# Altium schematic sheet LED_Monitor_2.SchDoc
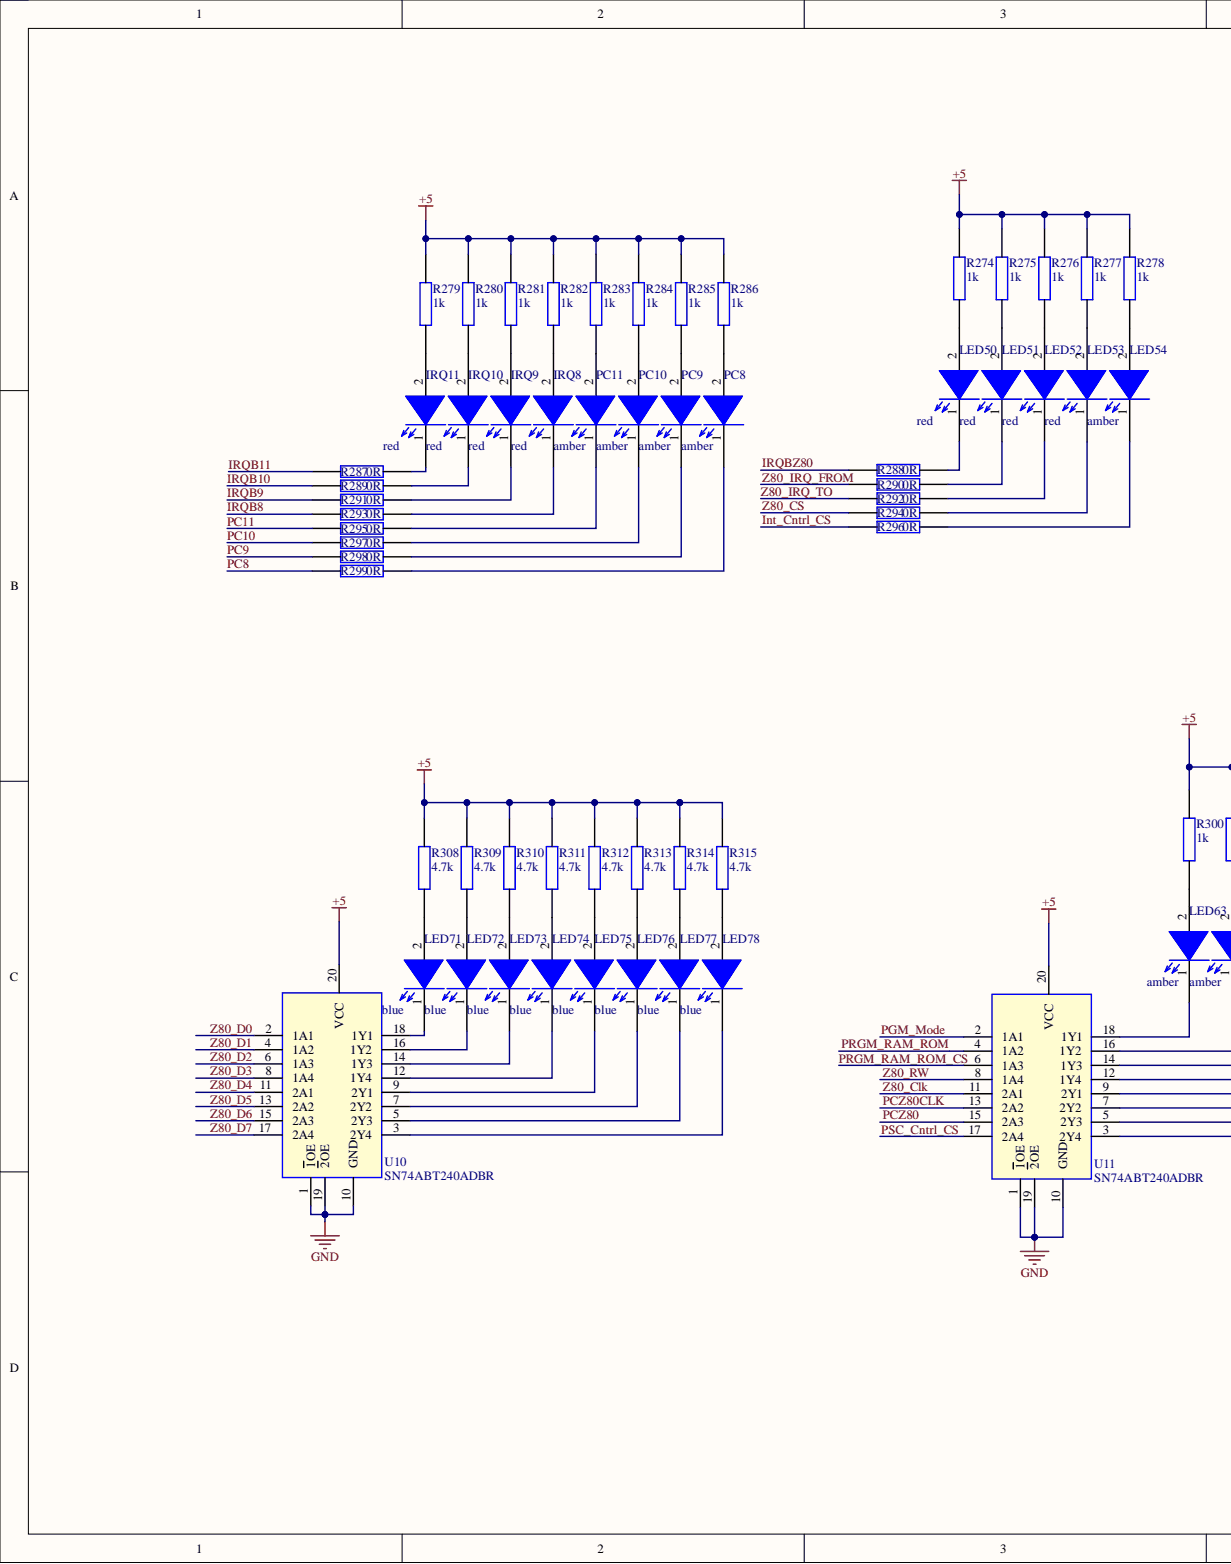
[diagram p0: LED_Monitor_2.SchDoc, left]
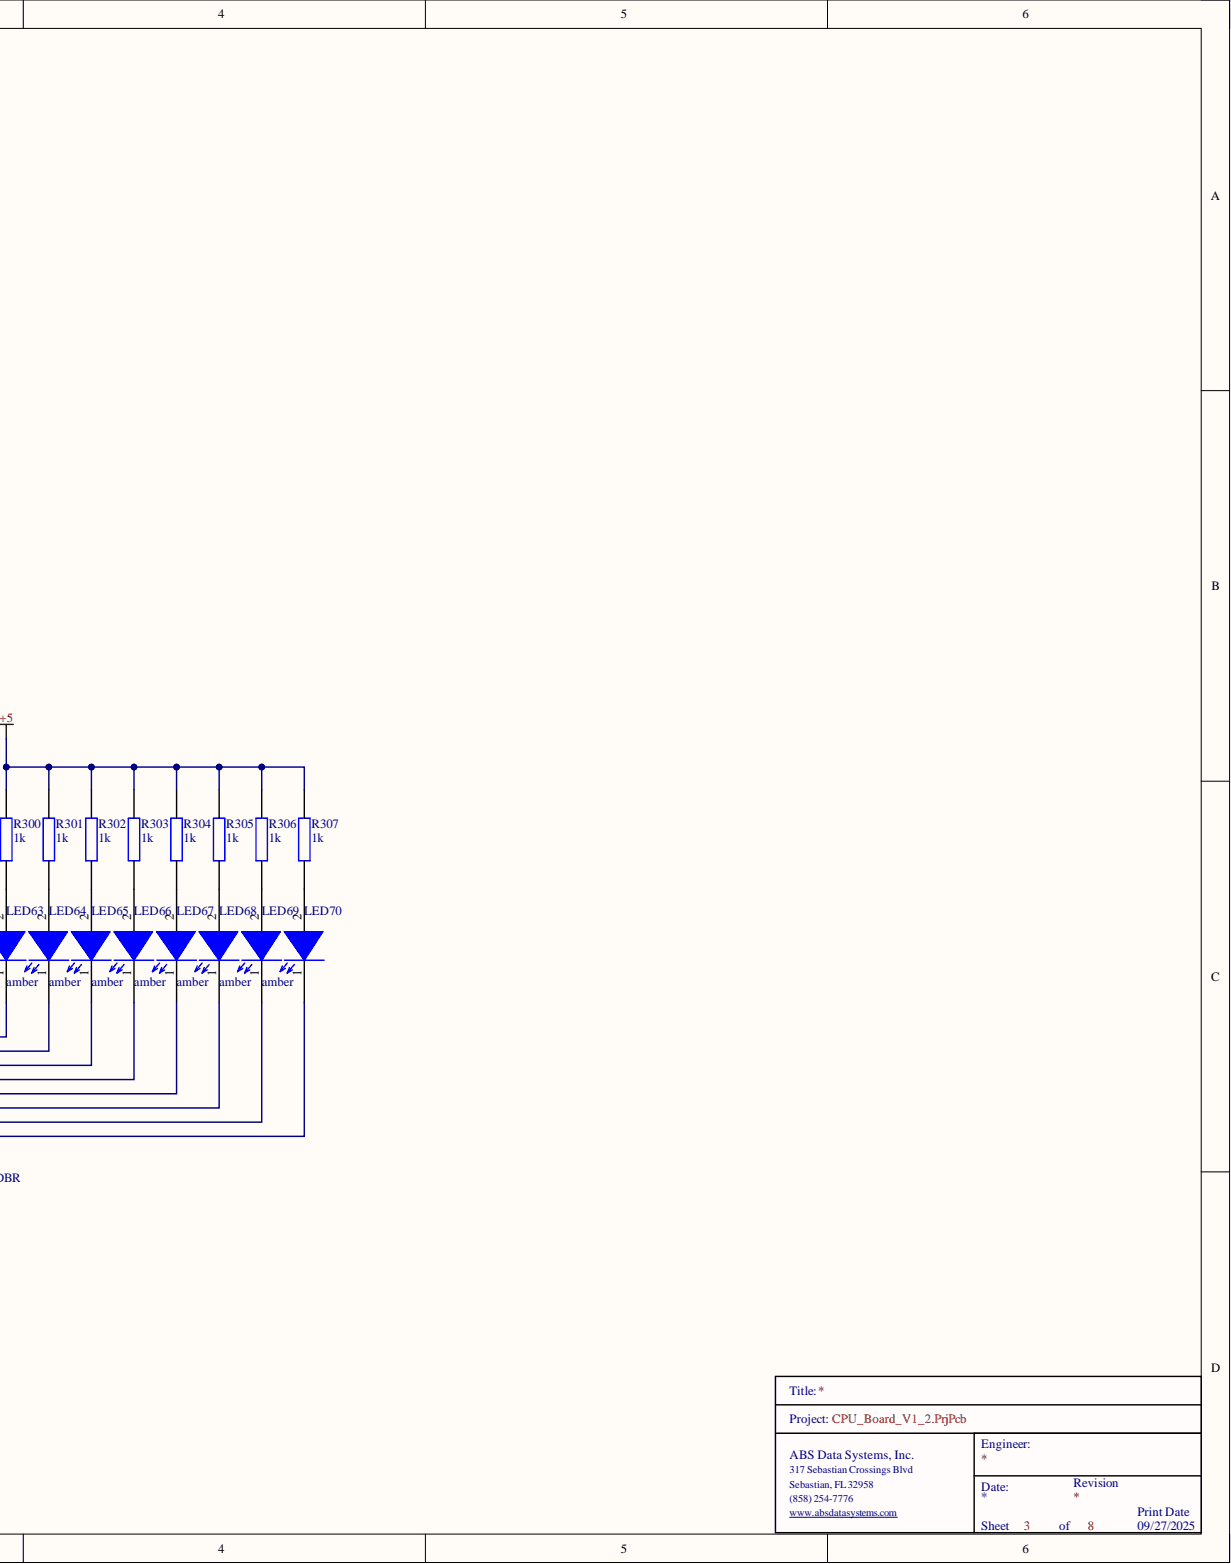
[diagram p1: LED_Monitor_2.SchDoc, right]

## Parts
IRQ8  red  [0603_Diode]
IRQ9  red  [0603_Diode]
IRQ10  red  [0603_Diode]
IRQ11  red  [0603_Diode]
LED50  red  [0603_Diode]
LED51  red  [0603_Diode]
LED52  red  [0603_Diode]
LED53  red  [0603_Diode]
LED54  amber  [0603_Diode]
LED63  amber  [0603_Diode]
LED64  amber  [0603_Diode]
LED65  amber  [0603_Diode]
LED66  amber  [0603_Diode]
LED67  amber  [0603_Diode]
LED68  amber  [0603_Diode]
LED69  amber  [0603_Diode]
LED70  amber  [0603_Diode]
LED71  blue  [0603_Diode]
LED72  blue  [0603_Diode]
LED73  blue  [0603_Diode]
LED74  blue  [0603_Diode]
LED75  blue  [0603_Diode]
LED76  blue  [0603_Diode]
LED77  blue  [0603_Diode]
LED78  blue  [0603_Diode]
PC8  amber  [0603_Diode]
PC9  amber  [0603_Diode]
PC10  amber  [0603_Diode]
PC11  amber  [0603_Diode]
R274  1k  [0603]
R275  1k  [0603]
R276  1k  [0603]
R277  1k  [0603]
R278  1k  [0603]
R279  1k  [0603]
R280  1k  [0603]
R281  1k  [0603]
R282  1k  [0603]
R283  1k  [0603]
R284  1k  [0603]
R285  1k  [0603]
R286  1k  [0603]
R287  0R  [0603]  (value not drawn)
R288  0R  [0603]  (value not drawn)
R289  0R  [0603]  (value not drawn)
R290  0R  [0603]  (value not drawn)
R291  0R  [0603]  (value not drawn)
R292  0R  [0603]  (value not drawn)
R293  0R  [0603]  (value not drawn)
R294  0R  [0603]  (value not drawn)
R295  0R  [0603]  (value not drawn)
R296  0R  [0603]  (value not drawn)
R297  0R  [0603]  (value not drawn)
R298  0R  [0603]  (value not drawn)
R299  0R  [0603]  (value not drawn)
R300  1k  [0603]
R301  1k  [0603]
R302  1k  [0603]
R303  1k  [0603]
R304  1k  [0603]
R305  1k  [0603]
R306  1k  [0603]
R307  1k  [0603]
R308  4.7k  [0603]
R309  4.7k  [0603]
R310  4.7k  [0603]
R311  4.7k  [0603]
R312  4.7k  [0603]
R313  4.7k  [0603]
R314  4.7k  [0603]
R315  4.7k  [0603]
U10  SN74ABT240ADBR  [SOP65P780X200-20N]
U11  SN74ABT240ADBR  [SOP65P780X200-20N]

## Nets
+5: R274.1 R275.1 R276.1 R277.1 R278.1 R279.1 R280.1 R281.1 R282.1 R283.1 R284.1 R285.1 R286.1 R300.1 R301.1 R302.1 R303.1 R304.1 R305.1 R306.1 R307.1 R308.1 R309.1 R310.1 R311.1 R312.1 R313.1 R314.1 R315.1 U10.20(VCC) U11.20(VCC)
GND: U10.1(1OE) U10.10(GND) U10.19(2OE) U11.1(1OE) U11.10(GND) U11.19(2OE)
Int_Cntrl_CS: R296.1
IRQB8: R293.1
IRQB9: R291.1
IRQB10: R289.1
IRQB11: R287.1
IRQBZ80: R288.1
PC8: R299.1
PC9: R298.1
PC10: R297.1
PC11: R295.1
PCZ80: U11.15(2A3)
PCZ80CLK: U11.13(2A2)
PGM_Mode: U11.2(1A1)
PRGM_RAM_ROM: U11.4(1A2)
PRGM_RAM_ROM_CS: U11.6(1A3)
PSC_Cntrl_CS: U11.17(2A4)
Z80_Clk: U11.11(2A1)
Z80_CS: R294.1
Z80_D0: U10.2(1A1)
Z80_D1: U10.4(1A2)
Z80_D2: U10.6(1A3)
Z80_D3: U10.8(1A4)
Z80_D4: U10.11(2A1)
Z80_D5: U10.13(2A2)
Z80_D6: U10.15(2A3)
Z80_D7: U10.17(2A4)
Z80_IRQ_FROM: R290.1
Z80_IRQ_TO: R292.1
Z80_RW: U11.8(1A4)
NetIRQ8_1: IRQ8.1 R293.2
NetIRQ8_2: IRQ8.2 R282.2
NetIRQ9_1: IRQ9.1 R291.2
NetIRQ9_2: IRQ9.2 R281.2
NetIRQ10_1: IRQ10.1 R289.2
NetIRQ10_2: IRQ10.2 R280.2
NetIRQ11_1: IRQ11.1 R287.2
NetIRQ11_2: IRQ11.2 R279.2
NetLED50_1: LED50.1 R288.2
NetLED50_2: LED50.2 R274.2
NetLED51_1: LED51.1 R290.2
NetLED51_2: LED51.2 R275.2
NetLED52_1: LED52.1 R292.2
NetLED52_2: LED52.2 R276.2
NetLED53_1: LED53.1 R294.2
NetLED53_2: LED53.2 R277.2
NetLED54_1: LED54.1 R296.2
NetLED54_2: LED54.2 R278.2
NetLED63_1: LED63.1 U11.18(1Y1)
NetLED63_2: LED63.2 R300.2
NetLED64_1: LED64.1 U11.16(1Y2)
NetLED64_2: LED64.2 R301.2
NetLED65_1: LED65.1 U11.14(1Y3)
NetLED65_2: LED65.2 R302.2
NetLED66_1: LED66.1 U11.12(1Y4)
NetLED66_2: LED66.2 R303.2
NetLED67_1: LED67.1 U11.9(2Y1)
NetLED67_2: LED67.2 R304.2
NetLED68_1: LED68.1 U11.7(2Y2)
NetLED68_2: LED68.2 R305.2
NetLED69_1: LED69.1 U11.5(2Y3)
NetLED69_2: LED69.2 R306.2
NetLED70_1: LED70.1 U11.3(2Y4)
NetLED70_2: LED70.2 R307.2
NetLED71_1: LED71.1 U10.18(1Y1)
NetLED71_2: LED71.2 R308.2
NetLED72_1: LED72.1 U10.16(1Y2)
NetLED72_2: LED72.2 R309.2
NetLED73_1: LED73.1 U10.14(1Y3)
NetLED73_2: LED73.2 R310.2
NetLED74_1: LED74.1 U10.12(1Y4)
NetLED74_2: LED74.2 R311.2
NetLED75_1: LED75.1 U10.9(2Y1)
NetLED75_2: LED75.2 R312.2
NetLED76_1: LED76.1 U10.7(2Y2)
NetLED76_2: LED76.2 R313.2
NetLED77_1: LED77.1 U10.5(2Y3)
NetLED77_2: LED77.2 R314.2
NetLED78_1: LED78.1 U10.3(2Y4)
NetLED78_2: LED78.2 R315.2
NetPC8_1: PC8.1 R299.2
NetPC8_2: PC8.2 R286.2
NetPC9_1: PC9.1 R298.2
NetPC9_2: PC9.2 R285.2
NetPC10_1: PC10.1 R297.2
NetPC10_2: PC10.2 R284.2
NetPC11_1: PC11.1 R295.2
NetPC11_2: PC11.2 R283.2

## Library data of the parts
IRQ8-IRQ11 = 150060RS75000: Wurth Elektronik 0603 red; Manufacturer: Wurth Electronics; Manufacturer Part Number: 150060GS75000; Dominant Wavelength: 520nm; Forward Current: 30mA; Forward Voltage: 3.2V; Lens Style: Clear,Rectangular; Luminous Intensity: 430mcd; Test Current: 20mA; Viewing Angle: 140°; Case Code (Imperial): 0603; Case Code (Metric): 1608; Case/Package: 0603; Category: LEDs; Color: Green; Component Kind: Standard; ComponentLink1Description: https://datasheet.ciiva.com/29562/150060gs75000-29562868.pdf?src-supplier=Digi-Key; ComponentLink1URL: https://datasheet.ciiva.com/29562/150060gs75000-29562868.pdf?src-supplier=Digi-Key; ComponentLink2Description: https://datasheet.ciiva.com/29279/759427-da-01-en-chipled-0603-w-kl-gruen-150060gs75000-29279201.pdf?src-supplier=Conrad; ComponentLink2URL: https://datasheet.ciiva.com/29279/759427-da-01-en-chipled-0603-w-kl-gruen-150060gs75000-29279201.pdf?src-supplier=Conrad; ComponentLink3Description: https://datasheet.ciiva.com/29562/150060gs75000-29562869.pdf?src-supplier=Digi-Key; ComponentLink3URL: https://datasheet.ciiva.com/29562/150060gs75000-29562869.pdf?src-supplier=Digi-Key; ComponentLink4Description: https://datasheet.ciiva.com/29562/150060gs75000-29562870.pdf?src-supplier=Digi-Key; ComponentLink4URL: https://datasheet.ciiva.com/29562/150060gs75000-29562870.pdf?src-supplier=Digi-Key; Height: 0.7mm; Lead Free: Lead Free; Length: 1.6mm; Library Name: Misc.SchLib; Max Operating Temperature: 85°C; Min Operating Temperature: -40°C; Mount: Surface Mount; Package Quantity: 4000; Packaging: Tape and Reel; Pricing 1: 1=0.14000, 50=0.13300, 100=0.11400, 500=0.09900 (USD); REACH SVHC: Unknown; RoHS: Yes; Stock 1: 71509; Supplier 1: Digi-Key; Wavelength: 520nm; Width: 0.8mm
LED50-LED53 = 150060RS75000: Wurth Elektronik 0603 red; Manufacturer: Wurth Electronics; Manufacturer Part Number: 150060GS75000; Color: Green; Dominant Wavelength: 520nm; Forward Current: 30mA; Forward Voltage: 3.2V; Lens Style: Clear,Rectangular; Luminous Intensity: 430mcd; Test Current: 20mA; Viewing Angle: 140°; Case Code (Imperial): 0603; Case Code (Metric): 1608; Case/Package: 0603; Category: LEDs; Component Kind: Standard; ComponentLink1Description: https://datasheet.ciiva.com/29562/150060gs75000-29562868.pdf?src-supplier=Digi-Key; ComponentLink1URL: https://datasheet.ciiva.com/29562/150060gs75000-29562868.pdf?src-supplier=Digi-Key; ComponentLink2Description: https://datasheet.ciiva.com/29279/759427-da-01-en-chipled-0603-w-kl-gruen-150060gs75000-29279201.pdf?src-supplier=Conrad; ComponentLink2URL: https://datasheet.ciiva.com/29279/759427-da-01-en-chipled-0603-w-kl-gruen-150060gs75000-29279201.pdf?src-supplier=Conrad; ComponentLink3Description: https://datasheet.ciiva.com/29562/150060gs75000-29562869.pdf?src-supplier=Digi-Key; ComponentLink3URL: https://datasheet.ciiva.com/29562/150060gs75000-29562869.pdf?src-supplier=Digi-Key; ComponentLink4Description: https://datasheet.ciiva.com/29562/150060gs75000-29562870.pdf?src-supplier=Digi-Key; ComponentLink4URL: https://datasheet.ciiva.com/29562/150060gs75000-29562870.pdf?src-supplier=Digi-Key; Height: 0.7mm; Lead Free: Lead Free; Length: 1.6mm; Library Name: Misc.SchLib; Max Operating Temperature: 85°C; Min Operating Temperature: -40°C; Mount: Surface Mount; Package Quantity: 4000; Packaging: Tape and Reel; Pricing 1: 1=0.14000, 50=0.13300, 100=0.11400, 500=0.09900 (USD); REACH SVHC: Unknown; RoHS: Yes; Stock 1: 71509; Supplier 1: Digi-Key; Wavelength: 520nm; Width: 0.8mm
LED54 LED63-LED70 = 150060AS75000: Wurth Elektronik 0603 amber; Manufacturer: Wurth Electronics; Manufacturer Part Number: 150060GS75000; Color: Green; Dominant Wavelength: 520nm; Forward Current: 30mA; Forward Voltage: 3.2V; Lens Style: Clear,Rectangular; Luminous Intensity: 430mcd; Test Current: 20mA; Viewing Angle: 140°; Case Code (Imperial): 0603; Case Code (Metric): 1608; Case/Package: 0603; Category: LEDs; Component Kind: Standard; ComponentLink1Description: https://datasheet.ciiva.com/29562/150060gs75000-29562868.pdf?src-supplier=Digi-Key; ComponentLink1URL: https://datasheet.ciiva.com/29562/150060gs75000-29562868.pdf?src-supplier=Digi-Key; ComponentLink2Description: https://datasheet.ciiva.com/29279/759427-da-01-en-chipled-0603-w-kl-gruen-150060gs75000-29279201.pdf?src-supplier=Conrad; ComponentLink2URL: https://datasheet.ciiva.com/29279/759427-da-01-en-chipled-0603-w-kl-gruen-150060gs75000-29279201.pdf?src-supplier=Conrad; ComponentLink3Description: https://datasheet.ciiva.com/29562/150060gs75000-29562869.pdf?src-supplier=Digi-Key; ComponentLink3URL: https://datasheet.ciiva.com/29562/150060gs75000-29562869.pdf?src-supplier=Digi-Key; ComponentLink4Description: https://datasheet.ciiva.com/29562/150060gs75000-29562870.pdf?src-supplier=Digi-Key; ComponentLink4URL: https://datasheet.ciiva.com/29562/150060gs75000-29562870.pdf?src-supplier=Digi-Key; Height: 0.7mm; Lead Free: Lead Free; Length: 1.6mm; Library Name: Misc.SchLib; Max Operating Temperature: 85°C; Min Operating Temperature: -40°C; Mount: Surface Mount; Package Quantity: 4000; Packaging: Tape and Reel; Pricing 1: 1=0.14000, 50=0.13300, 100=0.11400, 500=0.09900 (USD); REACH SVHC: Unknown; RoHS: Yes; Stock 1: 71509; Supplier 1: Digi-Key; Wavelength: 520nm; Width: 0.8mm
LED71-LED78 = 150060BS75000: Wurth Elektronik 0603 blue; Manufacturer: Wurth Electronics; Manufacturer Part Number: 150060GS75000; Color: Green; Dominant Wavelength: 520nm; Forward Current: 30mA; Forward Voltage: 3.2V; Lens Style: Clear,Rectangular; Luminous Intensity: 430mcd; Test Current: 20mA; Viewing Angle: 140°; Case Code (Imperial): 0603; Case Code (Metric): 1608; Case/Package: 0603; Category: LEDs; Component Kind: Standard; ComponentLink1Description: https://datasheet.ciiva.com/29562/150060gs75000-29562868.pdf?src-supplier=Digi-Key; ComponentLink1URL: https://datasheet.ciiva.com/29562/150060gs75000-29562868.pdf?src-supplier=Digi-Key; ComponentLink2Description: https://datasheet.ciiva.com/29279/759427-da-01-en-chipled-0603-w-kl-gruen-150060gs75000-29279201.pdf?src-supplier=Conrad; ComponentLink2URL: https://datasheet.ciiva.com/29279/759427-da-01-en-chipled-0603-w-kl-gruen-150060gs75000-29279201.pdf?src-supplier=Conrad; ComponentLink3Description: https://datasheet.ciiva.com/29562/150060gs75000-29562869.pdf?src-supplier=Digi-Key; ComponentLink3URL: https://datasheet.ciiva.com/29562/150060gs75000-29562869.pdf?src-supplier=Digi-Key; ComponentLink4Description: https://datasheet.ciiva.com/29562/150060gs75000-29562870.pdf?src-supplier=Digi-Key; ComponentLink4URL: https://datasheet.ciiva.com/29562/150060gs75000-29562870.pdf?src-supplier=Digi-Key; Height: 0.7mm; Lead Free: Lead Free; Length: 1.6mm; Library Name: Misc.SchLib; Max Operating Temperature: 85°C; Min Operating Temperature: -40°C; Mount: Surface Mount; Package Quantity: 4000; Packaging: Tape and Reel; Pricing 1: 1=0.14000, 50=0.13300, 100=0.11400, 500=0.09900 (USD); REACH SVHC: Unknown; RoHS: Yes; Stock 1: 71509; Supplier 1: Digi-Key; Wavelength: 520nm; Width: 0.8mm
PC8-PC11 = 150060AS75000: Wurth Elektronik 0603 amber; Manufacturer: Wurth Electronics; Manufacturer Part Number: 150060GS75000; Dominant Wavelength: 520nm; Forward Current: 30mA; Forward Voltage: 3.2V; Lens Style: Clear,Rectangular; Luminous Intensity: 430mcd; Test Current: 20mA; Viewing Angle: 140°; Case Code (Imperial): 0603; Case Code (Metric): 1608; Case/Package: 0603; Category: LEDs; Color: Green; Component Kind: Standard; ComponentLink1Description: https://datasheet.ciiva.com/29562/150060gs75000-29562868.pdf?src-supplier=Digi-Key; ComponentLink1URL: https://datasheet.ciiva.com/29562/150060gs75000-29562868.pdf?src-supplier=Digi-Key; ComponentLink2Description: https://datasheet.ciiva.com/29279/759427-da-01-en-chipled-0603-w-kl-gruen-150060gs75000-29279201.pdf?src-supplier=Conrad; ComponentLink2URL: https://datasheet.ciiva.com/29279/759427-da-01-en-chipled-0603-w-kl-gruen-150060gs75000-29279201.pdf?src-supplier=Conrad; ComponentLink3Description: https://datasheet.ciiva.com/29562/150060gs75000-29562869.pdf?src-supplier=Digi-Key; ComponentLink3URL: https://datasheet.ciiva.com/29562/150060gs75000-29562869.pdf?src-supplier=Digi-Key; ComponentLink4Description: https://datasheet.ciiva.com/29562/150060gs75000-29562870.pdf?src-supplier=Digi-Key; ComponentLink4URL: https://datasheet.ciiva.com/29562/150060gs75000-29562870.pdf?src-supplier=Digi-Key; Height: 0.7mm; Lead Free: Lead Free; Length: 1.6mm; Library Name: Misc.SchLib; Max Operating Temperature: 85°C; Min Operating Temperature: -40°C; Mount: Surface Mount; Package Quantity: 4000; Packaging: Tape and Reel; Pricing 1: 1=0.14000, 50=0.13300, 100=0.11400, 500=0.09900 (USD); REACH SVHC: Unknown; RoHS: Yes; Stock 1: 71509; Supplier 1: Digi-Key; Wavelength: 520nm; Width: 0.8mm
R274-R286 R300-R307 = AC0603FR-7W1KL_1: YAGEO 0603 1k ohm; Manufacturer_Name: KEMET; Manufacturer_Part_Number: RC0603JR-070RL; Geometry.Height: 0.55mm; Component Kind: Standard; Datasheet Link: https://datasheet.datasheetarchive.com/originals/distributors/Datasheets_SAMA/902f9e387b938f871d31120f5fc1d65e.pdf; Library Name: Resistors.SchLib; Mouser Part Number: 603-RC0603JR-070RL; Mouser Price/Stock: https://www.mouser.co.uk/ProductDetail/YAGEO/RC0603JR-070RL?qs=2cAdsCoAWRGWyPcuXgGiXQ%3D%3D
R287-R299 = RC0603JR-070RL: YAGEO 0603 0 ohm; Manufacturer_Name: KEMET; Geometry.Height: 0.55mm; Component Kind: Standard; Datasheet Link: https://datasheet.datasheetarchive.com/originals/distributors/Datasheets_SAMA/902f9e387b938f871d31120f5fc1d65e.pdf; Library Name: Resistors.SchLib; Manufacturer_Part_Number: RC0603JR-070RL; Mouser Part Number: 603-RC0603JR-070RL; Mouser Price/Stock: https://www.mouser.co.uk/ProductDetail/YAGEO/RC0603JR-070RL?qs=2cAdsCoAWRGWyPcuXgGiXQ%3D%3D
R308-R315 = RC0603FR-104K7L: YAGEO 0603 4.7k; Manufacturer_Name: KEMET; Manufacturer_Part_Number: RC0603JR-070RL; Geometry.Height: 0.55mm; Component Kind: Standard; Datasheet Link: https://datasheet.datasheetarchive.com/originals/distributors/Datasheets_SAMA/902f9e387b938f871d31120f5fc1d65e.pdf; Library Name: Resistors.SchLib; Mouser Part Number: 603-RC0603JR-070RL; Mouser Price/Stock: https://www.mouser.co.uk/ProductDetail/YAGEO/RC0603JR-070RL?qs=2cAdsCoAWRGWyPcuXgGiXQ%3D%3D
U10 U11 = SN74ABT240ADBR: Octal Buffers/Drivers With 3-State Outputs; Manufacturer_Name: Texas Instruments; Geometry.Height: 2mm; Component Kind: Standard; Datasheet Link: http://www.ti.com/lit/gpn/sn74abt240a; Library Name: Logic.SchLib; Manufacturer_Part_Number: SN74ABT240ADBR; Mouser Part Number: 595-SN74ABT240ADBR; Mouser Price/Stock: https://www.mouser.co.uk/ProductDetail/Texas-Instruments/SN74ABT240ADBR?qs=JHHQeKcAU3Dt82k%252BVyiYdw%3D%3D
